# Altium schematic sheet DM6010.SchDoc
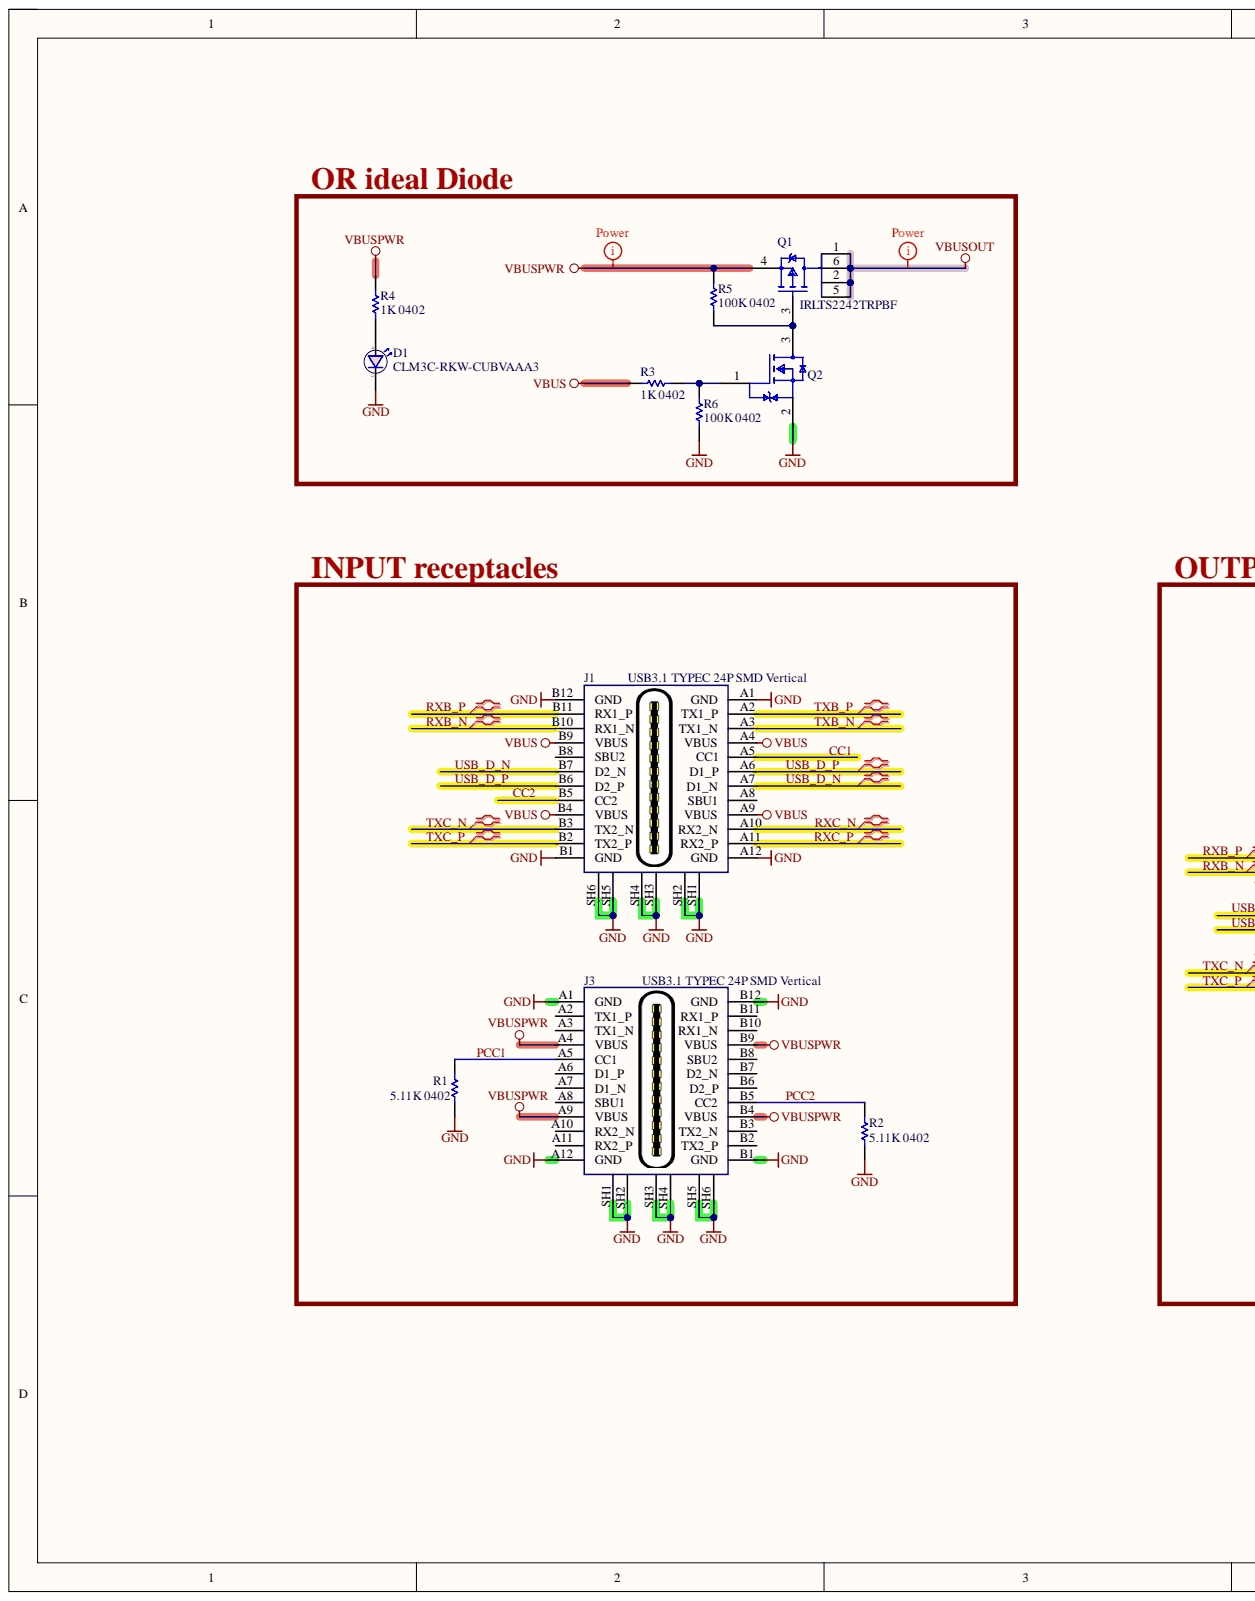
[diagram p0: DM6010.SchDoc, left]
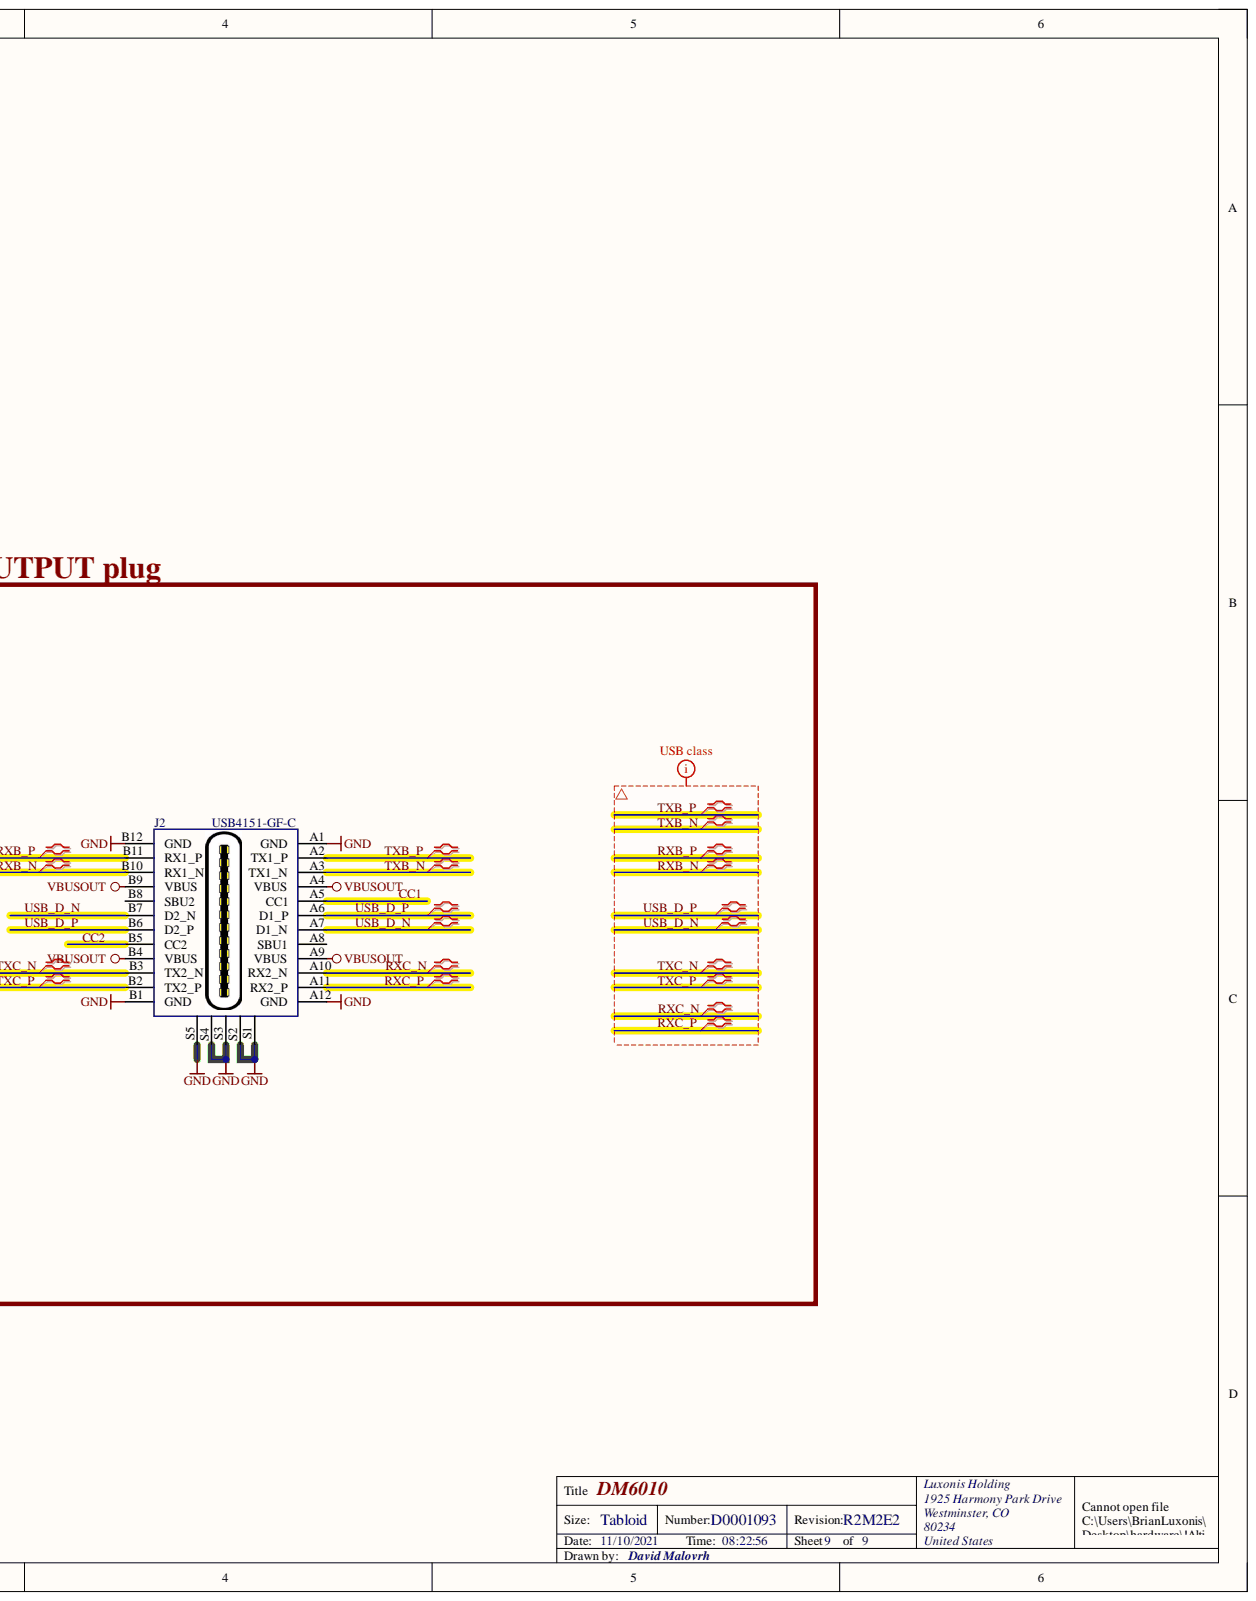
[diagram p1: DM6010.SchDoc, right]

## Parts
D1  CLM3C-RKW-CUBVAAA3  [CLM3C-RKW-CUBVAAA3]
J1  USB3.1 TYPEC 24P SMD Vertical  Orientation: Vertical  [CX80B1-24P]
J2  USB4151-GF-C  [GCT_USB4151-GF-C_REVA]
J3  USB3.1 TYPEC 24P SMD Vertical  Orientation: Vertical  [CX80B1-24P]
Q1  IRLTS2242TRPBF  [TSOP-6-MFG]
Q2  RE1C002UNTCL  [RE1C002UNTCL]  (value not drawn)
R1  5.11K 0402  Case Code (Imperial): 0402  [RESC0402(1005)_L]
R2  5.11K 0402  Case Code (Imperial): 0402  [RESC0402(1005)_L]
R3  1K 0402  Case Code (Imperial): 0402  [RESC0402(1005)_L]
R4  1K 0402  Case Code (Imperial): 0402  [RESC0402(1005)_L]
R5  100K 0402  [RESC0402(1005)_L]
R6  100K 0402  Case Code (Imperial): 0402  [RESC0402(1005)_L]

## Nets
GND: D1.2 J1.A1(GND) J1.A12(GND) J1.B1(GND) J1.B12(GND) J1.SH1 J1.SH2 J1.SH3 J1.SH4 J1.SH5 J1.SH6 J2.A1(GND) J2.A12(GND) J2.B1(GND) J2.B12(GND) J2.S1 J2.S2 J2.S3 J2.S4 J2.S5 J3.A1(GND) J3.A12(GND) J3.B1(GND) J3.B12(GND) J3.SH1 J3.SH2 J3.SH3 J3.SH4 J3.SH5 J3.SH6 Q2.2 R1.1 R2.1 R6.1
VBUS: J1.A4(VBUS) J1.A9(VBUS) J1.B4(VBUS) J1.B9(VBUS) R3.1
VBUSOUT: J2.A4(VBUS) J2.A9(VBUS) J2.B4(VBUS) J2.B9(VBUS) Q1.1 Q1.2 Q1.5 Q1.6
VBUSPWR: J3.A4(VBUS) J3.A9(VBUS) J3.B4(VBUS) J3.B9(VBUS) Q1.4 R4.2 R5.2
CC1: J1.A5(CC1) J2.A5(CC1)
CC2: J1.B5(CC2) J2.B5(CC2)
PCC1: J3.A5(CC1) R1.2
PCC2: J3.B5(CC2) R2.2
RXB_N: J1.B10(RX1_N) J2.B10(RX1_N)
RXB_P: J1.B11(RX1_P) J2.B11(RX1_P)
RXC_N: J1.A10(RX2_N) J2.A10(RX2_N)
RXC_P: J1.A11(RX2_P) J2.A11(RX2_P)
TXB_N: J1.A3(TX1_N) J2.A3(TX1_N)
TXB_P: J1.A2(TX1_P) J2.A2(TX1_P)
TXC_N: J1.B3(TX2_N) J2.B3(TX2_N)
TXC_P: J1.B2(TX2_P) J2.B2(TX2_P)
USB_D_N: J1.A7(D1_N) J1.B7(D2_N) J2.A7(D1_N) J2.B7(D2_N)
USB_D_P: J1.A6(D1_P) J1.B6(D2_P) J2.A6(D1_P) J2.B6(D2_P)
NetD1_1: D1.1 R4.1
NetQ1_3: Q1.3 Q2.3 R5.1
NetQ2_1: Q2.1 R3.2 R6.2

Not connected: J1 A8(SBU1) B8(SBU2); J2 A8(SBU1) B8(SBU2); J3 A2(TX1_P) A3(TX1_N) A6(D1_P) A7(D1_N) A8(SBU1) A10(RX2_N) A11(RX2_P) B2(TX2_P) B3(TX2_N) B6(D2_P) B7(D2_N) B8(SBU2) B10(RX1_N) B11(RX1_P)

## Library data of the parts
D1 = CLM3C-RKW-CUBVAAA3: Single Color LED, Red; Manufacturer 1: Cree; Additional Feature: HIGH RELIABILITY; Forward Current-Max: 50mA; Luminous Intensity-Nom: 730mcd; Number of Functions: 1; Overall Height: 1.3mm; Shape: Rectangular; Viewing Angle: 120°; Category: LEDs; Color: Red; Component Kind: Standard; ComponentLink1Description: https://datasheet.ciiva.com:443/pdfs/VipMasterIC/IC/CREE/CREE-S-A0009778054/CREE-S-A0009831191-1.pdf?src-supplier=IHS+Markit; ComponentLink1URL: https://datasheet.ciiva.com:443/pdfs/VipMasterIC/IC/CREE/CREE-S-A0009778054/CREE-S-A0009831191-1.pdf?src-supplier=IHS+Markit; ComponentLink2Description: https://datasheet.ciiva.com/15210/getdatasheetpartid-563038-15210357.pdf?src-supplier=Verical; ComponentLink2URL: https://datasheet.ciiva.com/15210/getdatasheetpartid-563038-15210357.pdf?src-supplier=Verical; ComponentLink3Description: https://datasheet.ciiva.com/2116/clm3crkwakw1156-2116358.pdf?src-supplier=Digi-Key; ComponentLink3URL: https://datasheet.ciiva.com/2116/clm3crkwakw1156-2116358.pdf?src-supplier=Digi-Key; Library Name: DM6010_R0M0E0.SCHLIB; Manufacturer Part Number 1: CLM3C-RKW-CUBVAAA3; Max Operating Temperature: 100°C; Min Operating Temperature: -40°C; Mount: Surface Mount; Number of Pins: 2; Optoelectronic Device Type: SINGLE COLOR LED; Packaging: Tape and Reel; Pricing 1: 1=0,16910, 10=0,15700, 25=0,15600, 3000=0,11060, 6000=0,10380, 9000=0,10200 (USD); REACH SVHC: No SVHC; RoHS: Yes; RoHS Compliant: Yes; Stock 1: 46; Supplier 1: Arrow Electronics; Supplier Part Number 1: CLM3C-RKW-CUBVAAA3
J1 J3 = CX80B1-24P: CONN RCPT USB3.1 TYPEC 24POS SMD Vertical; Manufacturer 1: Hirose Electric Co Ltd; Connector Type: USB; Current Rating: 1.25A; Gender: Receptacle; Mating Cycles: 10000; Number of Contacts: 24; Number of Ports: 1; Pitch: 0.5mm; Shielding: Shielded; Case/Package: 0101; Component Kind: Standard; ComponentLink1Description: Product page; ComponentLink1URL: https://www.jae.com/en/connectors/series/detail/product/id=66513; ComponentLink2Description: Digikey; ComponentLink2URL: https://www.digikey.com/en/products/detail/jae-electronics/DX07S024WJ3R400/6594044; Contact Material: Copper Alloy; Contact Plating: Gold; Features: Shielded; Flammability Rating: UL94 V-0; Housing Material: Thermoplastic; Insulation Color: Black; Length: 8.94mm; Library Name: DM6010_R0M0E0.SCHLIB; Manufacturer Part Number 1: CX80B1-24P; Max Operating Temperature: 80°C; Min Operating Temperature: -30°C; Mount: Surface Mount,Through Hole,Vertical; Number of Pins: 24; Number of Terminals: 24; Packaging: Tape and Reel; Pricing 1: 1=2.15000, 10=1.94900, 25=1.82960, 50=1.75000, 100=1.67050, 250=1.51140, 500=1.39208 (USD); REACH SVHC: Unknown; RoHS: Yes; Stock 1: 939; Supplier 1: Digi-Key; Supplier Part Number 1: 26-CX80B1-24PCT-ND; Termination: Solder; USB Standard: USB 3.1
J2 = USB4151-GF-C: USB Connector, 24 Contact(s), Male, Straight, Surface Mount Terminal, Locking, Plug; Manufacturer 1: Global Connector Technology; Component Kind: Standard; Library Name: DM6010_R0M0E0.SCHLIB; Manufacturer Part Number 1: USB4151-GF-C; Pricing 1: 1=1,89000, 10=1,74000, 25=1,62000, 50=1,50000, 100=1,35000, 250=1,20000 (USD); RoHS: Yes; Stock 1: 1006; Supplier 1: Newark; Supplier Part Number 1: 86AH2023
Q1 = IRLTS2242TRPBF: MOSFET P-CH 20V 6.9A 6TSOP; Manufacturer 1: Infineon; Drain to Source Voltage (Vdss): -20V; Gate to Source Voltage (Vgs): 12V; Input Capacitance: 905pF; Max Power Dissipation: 2W; Rds On Max: 32mR; Rise Time: 18ns; Threshold Voltage: -400mV; Case/Package: TSOP; Component Kind: Standard; Contact Plating: Tin; Continuous Drain Current (ID): 6.9A; Halogen Free: Halogen Free; Lead Free: Lead Free; Library Name: DM6010_R0M0E0.SCHLIB; Manufacturer Part Number 1: IRLTS2242TRPBF; Max Dual Supply Voltage: -20V; Max Operating Temperature: 150°C; Min Operating Temperature: -55°C; Mount: Surface Mount; Number of Pins: 6; Packaging: Tape and Reel; REACH SVHC: No SVHC; RoHS Compliant: Yes
Q2 = RE1C002UNTCL: VISHAY - SI1062X-T1-GE3 - MOSFET Transistor, N Channel, 530 mA, 20 V, 0.35 ohm, 4.5 V, 1 V; Manufacturer 1: Rohm; Continuous Drain Current (ID): 200mA; Drain to Source Breakdown Voltage: 20V; Drain to Source Resistance: 350mR; Drain-source On Resistance-Max: 420mR; Element Configuration: Single; Fall Time: 11ns; Gate to Source Voltage (Vgs): 8V; Input Capacitance: 25pF; Max Power Dissipation: 150mW; Number of Channels: 1; Polarity: N-CHANNEL; Power Dissipation: 220mW; Rds On Max: 1.2R; Rise Time: 14ns; Threshold Voltage: 1V; Turn-Off Delay Time: 16ns; Turn-On Delay Time: 2ns; Case/Package: SOT-89; Category: MOSFETs; Component Kind: Standard; ComponentLink1Description: http://www.mouser.com/ds/2/348/re1c002untcl-e-1018231.pdf?src-supplier=Mouser; ComponentLink1URL: http://www.mouser.com/ds/2/348/re1c002untcl-e-1018231.pdf?src-supplier=Mouser; ComponentLink2Description: http://rohmfs.rohm.com/en/techdata_basic/transistor/taping/EMT3_TL_taping.pdf?src-supplier=Digi-Key; ComponentLink2URL: http://rohmfs.rohm.com/en/techdata_basic/transistor/taping/EMT3_TL_taping.pdf?src-supplier=Digi-Key; ComponentLink3Description: http://rohmfs.rohm.com/en/products/databook/datasheet/discrete/transistor/mosfet/re1c002un.pdf?src-supplier=Avnet; ComponentLink3URL: http://rohmfs.rohm.com/en/products/databook/datasheet/discrete/transistor/mosfet/re1c002un.pdf?src-supplier=Avnet; ComponentLink4Description: https://www.rohm.com/datasheet/RE1C002UN/re1c002untcl-e?src-supplier=Digi-Key; ComponentLink4URL: https://www.rohm.com/datasheet/RE1C002UN/re1c002untcl-e?src-supplier=Digi-Key; ComponentLink5Description: http://www.farnell.com/datasheets/2704376.pdf?src-supplier=Newark; ComponentLink5URL: http://www.farnell.com/datasheets/2704376.pdf?src-supplier=Newark; ComponentLink6Description: http://www.farnell.com/datasheets/2704376.pdf?src-supplier=Farnell; ComponentLink6URL: http://www.farnell.com/datasheets/2704376.pdf?src-supplier=Farnell; ComponentLink7Description: http://www.farnell.com/datasheets/2704376.pdf?src-supplier=Element14; ComponentLink7URL: http://www.farnell.com/datasheets/2704376.pdf?src-supplier=Element14; ComponentLink8Description: https://datasheet.ciiva.com/6376/si1062x-6376310.pdf?src-supplier=Digi-Key; ComponentLink8URL: https://datasheet.ciiva.com/6376/si1062x-6376310.pdf?src-supplier=Digi-Key; ComponentLink9Description: https://datasheet.ciiva.com/24011/si1062x-256537-24011195.pdf?src-supplier=Mouser; ComponentLink9URL: https://datasheet.ciiva.com/24011/si1062x-256537-24011195.pdf?src-supplier=Mouser; ComponentLink10Description: https://datasheet.ciiva.com/6376/si1062x-6376251.pdf?src-supplier=Digi-Key; ComponentLink10URL: https://datasheet.ciiva.com/6376/si1062x-6376251.pdf?src-supplier=Digi-Key; Drain to Source Voltage (Vdss): 20V; Lead Free: Lead Free; Manufacturer 2: Vishay Siliconix; Manufacturer Part Number 1: RE1C002UNTCL; Manufacturer Part Number 2: SI1062X-T1-GE3; Max Operating Temperature: 150°C; Min Operating Temperature: -55°C; Mount: Surface Mount; Number of Elements: 1; Number of Pins: 3; On-State Resistance: 420mR; Package Quantity: 3000; Packaging: Tape and Reel; Pricing 1: 1=0.20000, 10=0.18200, 100=0.09930, 500=0.06112, 1000=0.04167 (USD); Pricing 2: 1=0,47000, 10=0,33100, 25=0,30800, 100=0,16720, 500=0,13640, 1000=0,10120 (USD); REACH SVHC: No SVHC; RoHS: Yes; RoHS Compliant: Yes; Series: TrenchFET®; Stock 1: 868886; Stock 2: 28076; Supplier 1: Digi-Key; Supplier 2: Digi-Key; Supplier 3: Digi-Key; Supplier Part Number 1: RE1C002UNTCLCT-ND; Supplier Part Number 2: SI1062X-T1-GE3CT-ND; Supplier Part Number 3: SI1062X-T1-GE3TR-ND; Weight: 0.001058oz; Library Name: LuxonisMaster.SchLib
R1 R2 = RC0402FR-075K11L: RES SMD 5.11K OHM 1% 1/16W 0402 5.11 kOhms ±1% 0.063W, 1/16W Chip Resistor 0402 (1005 Metric) Moisture Resistant Thick Film; Manufacturer 1: Yageo; Max Power Dissipation: 63mW; Power Rating: 62.5mW; Resistance: 5.11kR; Temperature Coefficient: 100ppm/°C; Voltage Rating: 50V; Case Code (Metric): 1005; Case/Package: 0402; Component Kind: Standard; ComponentLink1Description: https://datasheet.ciiva.com/2518/pyu-r-int-thick-7-2518982.pdf?src-supplier=Digi-Key; ComponentLink1URL: https://datasheet.ciiva.com/2518/pyu-r-int-thick-7-2518982.pdf?src-supplier=Digi-Key; ComponentLink2Description: https://datasheet.ciiva.com/2518/pyu-r-int-thick-7-2518983.pdf?src-supplier=Digi-Key; ComponentLink2URL: https://datasheet.ciiva.com/2518/pyu-r-int-thick-7-2518983.pdf?src-supplier=Digi-Key; ComponentLink3Description: https://datasheet.ciiva.com/5684/1018594-5684622.pdf?src-supplier=Farnell; ComponentLink3URL: https://datasheet.ciiva.com/5684/1018594-5684622.pdf?src-supplier=Farnell; ComponentLink4Description: https://datasheet.ciiva.com/16743/pyu-rc0402-51-rohs-l-6-r-16743871.pdf?src-supplier=Future+Electronics; ComponentLink4URL: https://datasheet.ciiva.com/16743/pyu-rc0402-51-rohs-l-6-r-16743871.pdf?src-supplier=Future+Electronics; Composition: Thick Film; Depth: 0.5mm; Features: Moisture Resistant; Height: 0.016inch; Lead Free: Lead Free; Length: 1mm; Manufacturer Part Number 1: RC0402FR-075K11L; Max Operating Temperature: 155°C; Military Standard: Not; Min Operating Temperature: -55°C; Number of Terminations: 2; Package Quantity: 1; Packaging: Tape and Reel; Pricing 1: 1=0.10000, 10=0.01600, 100=0.00670, 1000=0.00301, 2500=0.00261, 5000=0.00215 (USD); RoHS: Yes; Stock 1: 1263456; Supplier 1: Digi-Key; Supplier Part Number 1: 311-5.11KLRCT-ND; Termination: SMD/SMT; Tolerance: 1%; Voltage Rating (DC): 50V; Width: 0.02inch; Working Voltage: 50V; Library Name: LuxonisMaster.SchLib
R3 R4 = RC0402FR-071KL: RES SMD 1K OHM 1% 1/16W 0402 1 kOhms ±1% 0.063W, 1/16W Chip Resistor 0402 (1005 Metric) Moisture Resistant Thick Film; Manufacturer 1: Yageo; Power Rating: 62.5mW; Resistance: 1kR; Temperature Coefficient: 100ppm/°C; Voltage Rating: 50V; Case Code (Metric): 1005; Case/Package: 0402; Component Kind: Standard; ComponentLink1Description: https://datasheet.ciiva.com/11819/0900766b80c66297-11819477.pdf?src-supplier=RSComponents; ComponentLink1URL: https://datasheet.ciiva.com/11819/0900766b80c66297-11819477.pdf?src-supplier=RSComponents; ComponentLink2Description: https://datasheet.ciiva.com/12666/pyu-rc0402-51-rohs-l-6-r-12666741.pdf?src-supplier=Mouser; ComponentLink2URL: https://datasheet.ciiva.com/12666/pyu-rc0402-51-rohs-l-6-r-12666741.pdf?src-supplier=Mouser; ComponentLink3Description: https://datasheet.ciiva.com/17063/pyu-rc0402-51-rohs-l-6-r-17063193.pdf?src-supplier=Future+Electronics; ComponentLink3URL: https://datasheet.ciiva.com/17063/pyu-rc0402-51-rohs-l-6-r-17063193.pdf?src-supplier=Future+Electronics; ComponentLink4Description: https://datasheet.ciiva.com/22747/1018594-22747513.pdf?src-supplier=Element14; ComponentLink4URL: https://datasheet.ciiva.com/22747/1018594-22747513.pdf?src-supplier=Element14; ComponentLink5Description: https://datasheet.ciiva.com/7849/1018594-7849869.pdf?src-supplier=Element14; ComponentLink5URL: https://datasheet.ciiva.com/7849/1018594-7849869.pdf?src-supplier=Element14; ComponentLink6Description: https://datasheet.ciiva.com/7983/1018594-7983502.pdf?src-supplier=Element14; ComponentLink6URL: https://datasheet.ciiva.com/7983/1018594-7983502.pdf?src-supplier=Element14; ComponentLink7Description: https://datasheet.ciiva.com/7849/1018594-7849871.pdf?src-supplier=Element14; ComponentLink7URL: https://datasheet.ciiva.com/7849/1018594-7849871.pdf?src-supplier=Element14; Composition: Thick Film; Depth: 0.5mm; Height: 0.32mm; Lead Free: Lead Free; Length: 1mm; Manufacturer Part Number 1: RC0402FR-071KL; Max Operating Temperature: 155°C; Military Standard: Not; Min Operating Temperature: -55°C; Number of Pins: 2; Packaging: Tape and Reel; Pricing 1: 1=0.10000, 10=0.01600, 100=0.00670, 1000=0.00301, 2500=0.00261, 5000=0.00215 (USD); REACH SVHC: Unknown; RoHS: Yes; Stock 1: 60695854; Supplier 1: Digi-Key; Supplier Part Number 1: 311-1.00KLRCT-ND; Termination: SMD/SMT; Tolerance: 1%; Voltage Rating (DC): 50V; Width: 0.5mm; Library Name: LuxonisMaster.SchLib
R5 R6 = RC0402FR-07100KL: RES SMD 100K OHM 1% 1/16W 0402; Manufacturer 1: Yageo; Max Power Dissipation: 63mW; Resistance: 100kR; Temperature Coefficient: 100ppm/°C; Voltage Rating: 50V; Case Code (Metric): 1005; Case/Package: 0402; Component Kind: Standard; ComponentLink1Description: https://datasheet.ciiva.com/11819/0900766b80c66297-11819452.pdf?src-supplier=RSComponents; ComponentLink1URL: https://datasheet.ciiva.com/11819/0900766b80c66297-11819452.pdf?src-supplier=RSComponents; ComponentLink2Description: https://datasheet.ciiva.com/2453/pyu-r-int-thick-7-2453361.pdf?src-supplier=Digi-Key; ComponentLink2URL: https://datasheet.ciiva.com/2453/pyu-r-int-thick-7-2453361.pdf?src-supplier=Digi-Key; ComponentLink3Description: https://datasheet.ciiva.com/2453/pyu-r-int-thick-7-2453362.pdf?src-supplier=Digi-Key; ComponentLink3URL: https://datasheet.ciiva.com/2453/pyu-r-int-thick-7-2453362.pdf?src-supplier=Digi-Key; ComponentLink4Description: https://datasheet.ciiva.com/2453/pyu-r-int-thick-7-2453363.pdf?src-supplier=Digi-Key; ComponentLink4URL: https://datasheet.ciiva.com/2453/pyu-r-int-thick-7-2453363.pdf?src-supplier=Digi-Key; ComponentLink5Description: https://datasheet.ciiva.com/22747/1018594-22747509.pdf?src-supplier=Element14; ComponentLink5URL: https://datasheet.ciiva.com/22747/1018594-22747509.pdf?src-supplier=Element14; ComponentLink6Description: https://datasheet.ciiva.com/15189/getdatasheetpartid-323696-15189334.pdf?src-supplier=Verical; ComponentLink6URL: https://datasheet.ciiva.com/15189/getdatasheetpartid-323696-15189334.pdf?src-supplier=Verical; ComponentLink7Description: https://datasheet.ciiva.com/12667/pyu-rc0402-51-rohs-l-6-r-12667930.pdf?src-supplier=Mouser; ComponentLink7URL: https://datasheet.ciiva.com/12667/pyu-rc0402-51-rohs-l-6-r-12667930.pdf?src-supplier=Mouser; Composition: Thick Film; Depth: 0.5mm; Features: Moisture Resistant; Height: 0.016inch; Lead Free: Lead Free; Length: 0.039inch; Manufacturer Part Number 1: RC0402FR-07100KL; Max Operating Temperature: 155°C; Military Standard: Not; Min Operating Temperature: -55°C; Number of Terminations: 2; Package Quantity: 1; Packaging: Tape and Reel; Power Rating: 63mW; Pricing 1: 1=0.10000, 10=0.01500, 100=0.00600, 1000=0.00270, 2500=0.00235, 5000=0.00194 (USD); REACH SVHC: No SVHC; RoHS: Yes; Stock 1: 50867324; Supplier 1: Digi-Key; Supplier 2: Newark; Supplier 3: Mouser; Supplier Part Number 1: 311-100KLRCT-ND; Supplier Part Number 2: 67R9887; Supplier Part Number 3: 603-RC0402FR-07100KL; Termination: SMD/SMT; Tolerance: 1%; Width: 0.02inch; Working Voltage: 50V; Library Name: LuxonisMaster.SchLib
  R5: Case Code (Imperial): 0402
